# Altium schematic sheet Sheet.SchDoc
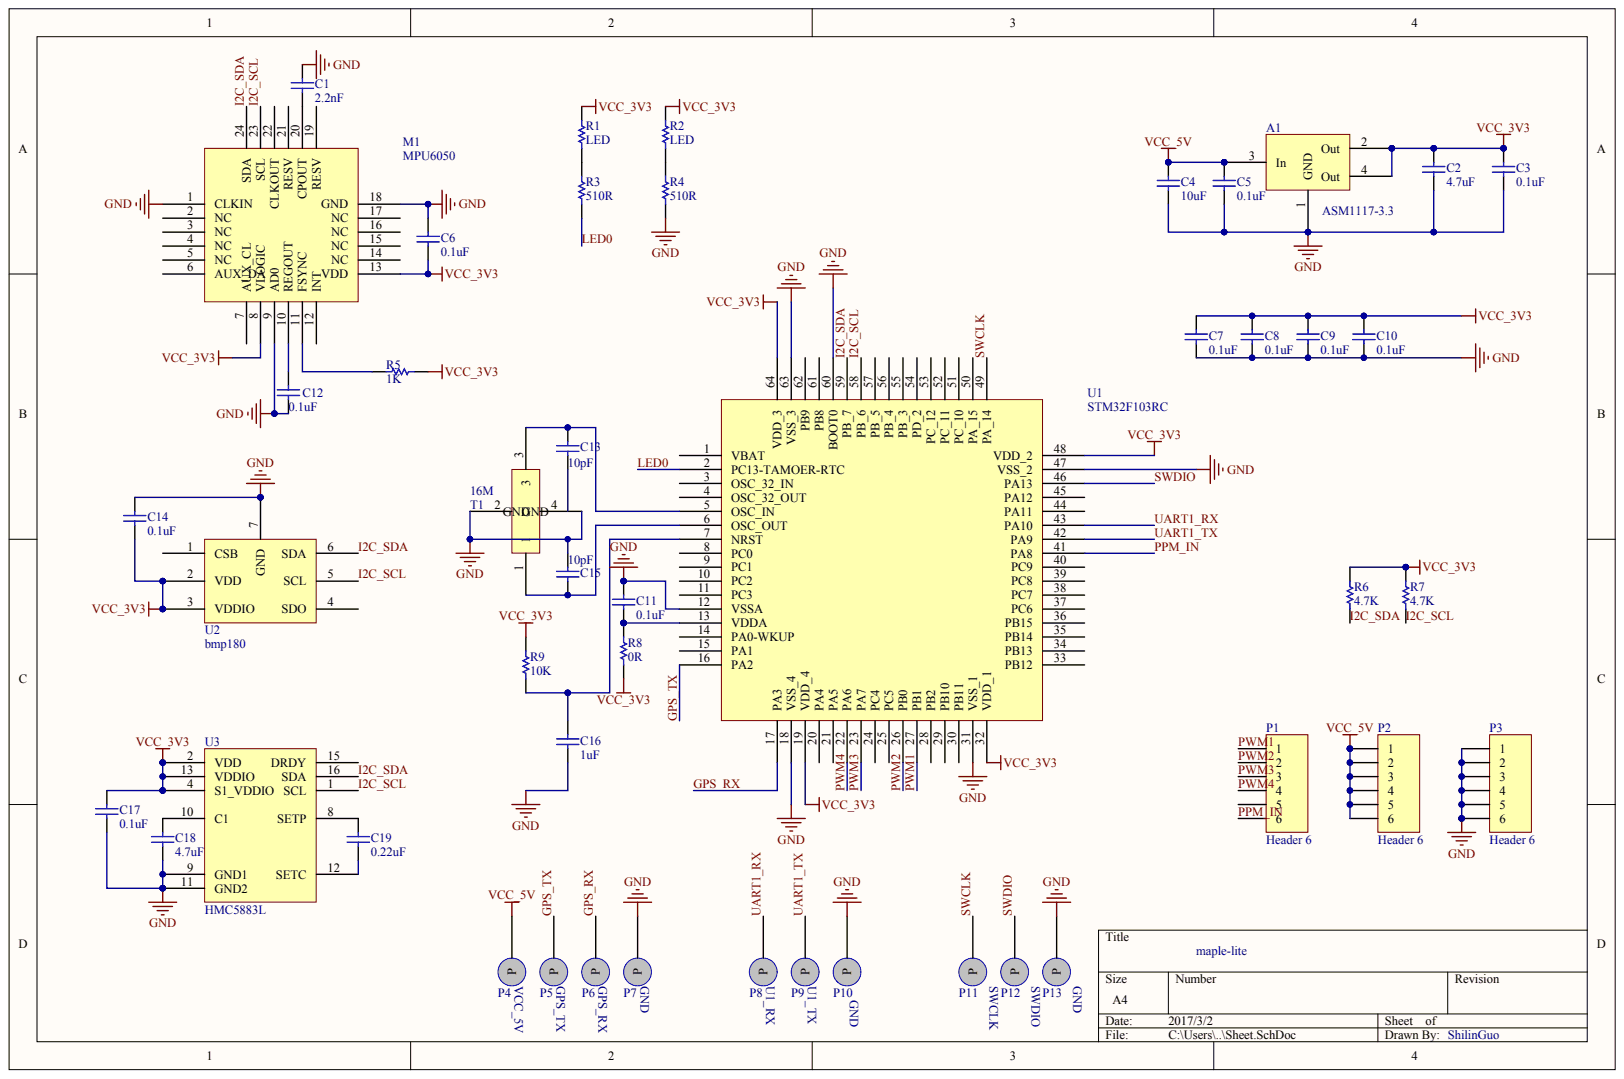
[diagram p0: Sheet.SchDoc]

## Parts
A1  ASM1117-3.3
C1  2.2nF
C2  4.7uF
C3  0.1uF
C4  10uF
C5  0.1uF
C6  0.1uF
C7  0.1uF
C8  0.1uF
C9  0.1uF
C10  0.1uF
C11  0.1uF
C12  0.1uF
C13  10pF
C14  0.1uF
C15  10pF
C16  1uF
C17  0.1uF
C18  4.7uF
C19  0.22uF
M1  MPU6050
P1  Header 6
P2  Header 6
P3  Header 6
P4  VCC_5V
P5  GPS_TX
P6  GPS_RX
P7  GND
P8  U1_RX
P9  U1_TX
P10  GND
P11  SWCLK
P12  SWDIO
P13  GND
R1  LED
R2  LED
R3  510R
R4  510R
R5  1K
R6  4.7K
R7  4.7K
R8  0R
R9  10K
T1  16M
U1  STM32F103RC
U2  bmp180
U3  HMC5883L

## Nets
GND: A1.1(GND) C1.2 C2.1 C3.1 C4.1 C5.1 C6.2 C7.1 C8.1 C9.1 C10.1 C11.2 C12.1 C14.2 C15.2 C16.1 C17.1 C18.1 M1.1(CLKIN) M1.9(AD0) M1.18(GND) P3.1 P3.2 P3.3 P3.4 P3.5 P3.6 P7.1 P10.1 P13.1 R4.1 T1.2(GND) T1.4(GND) U1.12(VSSA) U1.18(VSS_4) U1.31(VSS_1) U1.47(VSS_2) U1.60(BOOT0) U1.63(VSS_3) U2.7(GND) U3.9(GND1) U3.11(GND2)
VCC_3V3: A1.2(Out) A1.4(Out) C2.2 C3.2 C6.1 C7.2 C8.2 C9.2 C10.2 C14.1 C17.2 M1.8(VLOGIC) M1.13(VDD) R1.2 R2.2 R5.1 R6.2 R7.2 R8.1 R9.2 U1.19(VDD_4) U1.32(VDD_1) U1.48(VDD_2) U1.64(VDD_3) U2.2(VDD) U2.3(VDDIO) U3.2(VDD) U3.4(S1_VDDIO) U3.13(VDDIO)
VCC_5V: A1.3(In) C4.2 C5.2 P2.1 P2.2 P2.3 P2.4 P2.5 P2.6 P4.1
GPS_RX: P6.1 U1.17(PA3)
GPS_TX: P5.1 U1.16(PA2)
I2C_SCL: M1.23(SCL) R7.1 U1.58(PB_6) U2.5(SCL) U3.1(SCL)
I2C_SDA: M1.24(SDA) R6.1 U1.59(PB_7) U2.6(SDA) U3.16(SDA)
LED0: R3.1 U1.2(PC13-TAMOER-RTC)
PPM_IN: P1.6 U1.41(PA8)
PWM1: P1.1 U1.27(PB1)
PWM2: P1.2 U1.26(PB0)
PWM3: P1.3 U1.23(PA7)
PWM4: P1.4 U1.22(PA6)
SWCLK: P11.1 U1.49(PA_14)
SWDIO: P12.1 U1.46(PA13)
UART1_RX: P8.1 U1.43(PA10)
UART1_TX: P9.1 U1.42(PA9)
NetC1_1: C1.1 M1.20(CPOUT)
NetC11_1: C11.1 R8.2 U1.13(VDDA)
NetC12_2: C12.2 M1.10(REGOUT)
NetC13_2: C13.2 T1.3 U1.5(OSC_IN)
NetC15_1: C15.1 T1.1 U1.6(OSC_OUT)
NetC16_2: C16.2 R9.1 U1.7(NRST)
NetC18_2: C18.2 U3.10(C1)
NetC19_1: C19.1 U3.12(SETC)
NetC19_2: C19.2 U3.8(SETP)
NetM1_11: M1.11(FSYNC) R5.2
NetR1_1: R1.1 R3.2
NetR2_1: R2.1 R4.2

Not connected: C13 1; M1 2(NC) 3(NC) 4(NC) 5(NC) 6(AUX_DA) 7(AUX_CL) 12(INT) 14(NC) 15(NC) 16(NC) 17(NC) 19(RESV) 21(RESV) 22(CLKOUT); P1 5; U1 1(VBAT) 3(OSC_32_IN) 4(OSC_32_OUT) 8(PC0) 9(PC1) 10(PC2) 11(PC3) 14(PA0-WKUP) 15(PA1) 20(PA4) 21(PA5) 24(PC4) 25(PC5) 28(PB2) 29(PB10) 30(PB11) 33(PB12) 34(PB13) 35(PB14) 36(PB15) 37(PC6) 38(PC7) 39(PC8) 40(PC9) 44(PA11) 45(PA12) 50(PA_15) 51(PC_10) 52(PC_11) 53(PC_12) 54(PD_2) 55(PB_3) 56(PB_4) 57(PB_5) 61(PB8) 62(PB9); U2 1(CSB) 4(SDO); U3 15(DRDY)

## Library data of the parts
A1 C1-C19 M1 P1-P13 R1-R9 T1 U1-U3 = (no symbol name)
  P1-P3: LatestRevisionDate: 17-Jul-2002; LatestRevisionNote: Re-released for DXP Platform.; Publisher: Altium Limited
